# Altium schematic sheet 1_RPi.SchDoc
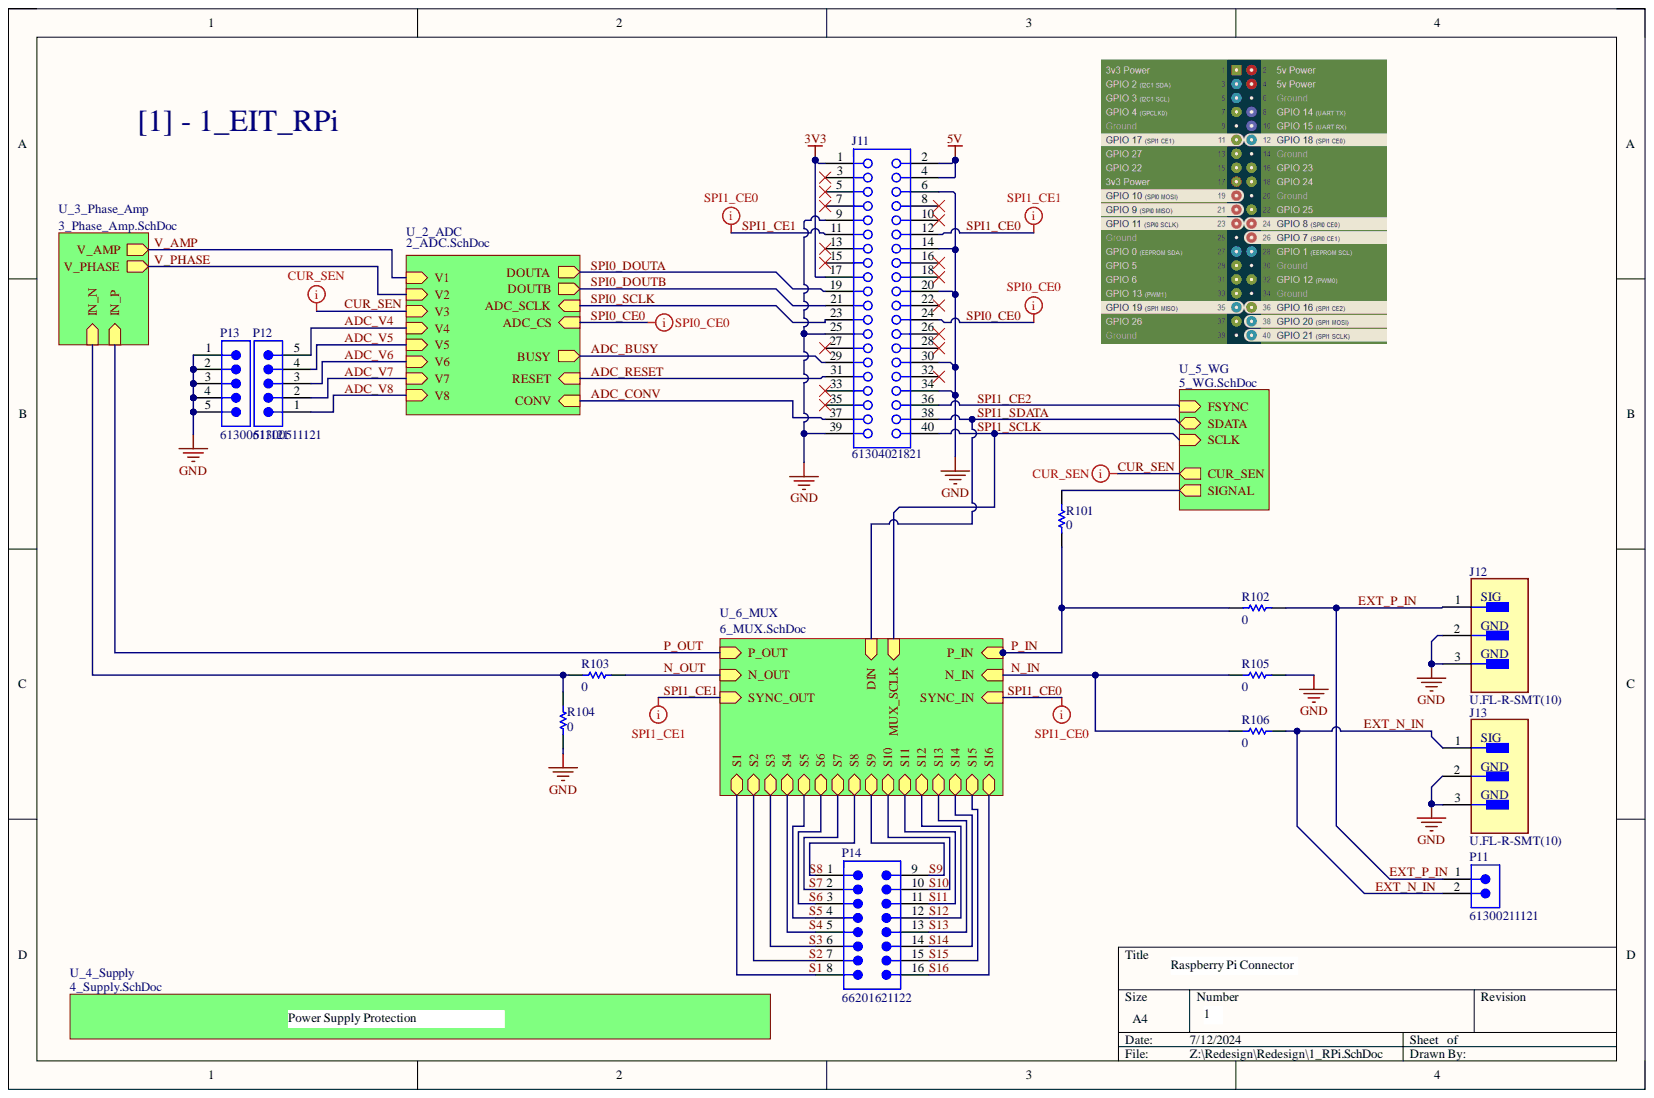
[diagram p0: 1_RPi.SchDoc]

## Parts
J11  61304021821  [61304021821]
J12  U.FL-R-SMT(10)  [FP-U_FL-R-SMT_10-MFG]
J13  U.FL-R-SMT(10)  [FP-U_FL-R-SMT_10-MFG]
P11  61300211121  [61300211121]
P12  61300511121  [61300511121]
P13  61300511121  [61300511121]
P14  66201621122  [66201621122]
R101  RC0603JR-070RL  [RESC1608X55X25LL10T15]  (value not drawn)
R102  RC0603JR-070RL  [RESC1608X55X25LL10T15]  (value not drawn)
R103  RC0603JR-070RL  [RESC1608X55X25LL10T15]  (value not drawn)
R104  RC0603JR-070RL  [RESC1608X55X25LL10T15]  (value not drawn)
R105  RC0603JR-070RL  [RESC1608X55X25LL10T15]  (value not drawn)
R106  RC0603JR-070RL  [RESC1608X55X25LL10T15]  (value not drawn)

## Nets
3V3: J11.1 J11.17
5V: J11.2 J11.4
GND: J11.6 J11.9 J11.14 J11.20 J11.25 J11.30 J11.34 J11.39 J12.2 J12.3 J13.2 J13.3 P13.1 P13.2 P13.3 P13.4 P13.5 R104.2 R105.1
ADC_BUSY: J11.29
ADC_CONV: J11.37
ADC_RESET: J11.31
ADC_V4: P12.5
ADC_V5: P12.4
ADC_V6: P12.3
ADC_V7: P12.2
ADC_V8: P12.1
EXT_N_IN: J13.1 P11.2 R106.1
EXT_P_IN: J12.1 P11.1 R102.1
N_IN: R105.2 R106.2
N_OUT: R103.1
P_IN: R101.2 R102.2
S1: P14.8
S2: P14.7
S3: P14.6
S4: P14.5
S5: P14.4
S6: P14.3
S7: P14.2
S8: P14.1
S9: P14.9
S10: P14.10
S11: P14.11
S12: P14.12
S13: P14.13
S14: P14.14
S15: P14.15
S16: P14.16
SPI0_CE0: J11.24
SPI0_DOUTA: J11.19
SPI0_DOUTB: J11.21
SPI0_SCLK: J11.23
SPI1_CE0: J11.12
SPI1_CE1: J11.11
SPI1_CE2: J11.36
SPI1_SCLK: J11.40
SPI1_SDATA: J11.38
NetR103_2: R103.2 R104.1
CUR_SEN:
P_OUT:
V_AMP:
V_PHASE:

Not connected: J11 3 5 7 8 10 13 15 16 18 22 26 27 28 32 33 35; R101 1

## Library data of the parts
J11 = CMP-1502-01370-3: NexarPartId: 61924769; Contact Resistance: 20mΩ; Current Rating: 3A; Gender: Female; Operating Supply Voltage: 250V; Component Kind: Standard; Lead Pitch: 2.54mm; Library Name: Altium Content Vault; Number of Pins: 40; Orientation: Vertical; RoHS: Non-Compliant
J12 J13 = eef6fde44c591823e8076210edfe12f: CONN U.FL RCPT STR 50 OHM SMD; Body Material: Bronze; Body Plating: Silver; Connector Type: Coaxial; Dielectric Material: Polymer; Frequency: 6GHz; Impedance: 50R; Case/Package: SMD/SMT; Component Kind: Standard; Contact Material: Brass; Contact Plating: Gold; Depth: 3.1mm; Fastening Type: Snap; Housing Color: Beige; Lead Free: Lead Free; Length: 3mm; Max Frequency: 6GHz; Max Operating Temperature: 90°C; Min Operating Temperature: -40°C; Mount: Surface Mount; Orientation: Vertical; Package Quantity: 1; Packaging: Tape and Reel; Plating: Silver; Radiation Hardening: No; Termination: Solder; Library Name: RPI_EIT_SchLib.SchLib
P11 = CMP-1712-00002-6: NexarPartId: 20081794; Connector Type: Male Pin; Contact Resistance: 20mΩ; Current Rating: 3A; Gender: Male; Number of Contacts: 2; Operating Supply Voltage: 250V; Pitch: 2.54mm; Color: Black; Component Kind: Standard; Contact Gender: Male; Contact Material: Copper; Contact Mating Length: 5.9944mm; Contact Plating: Gold; Height: 8.94mm; Housing Material: PBT; Lead Free: Lead Free; Lead Pitch: 2.54mm; Library Name: Altium Content Vault; Max Operating Temperature: 125°C; Min Operating Temperature: -40°C; Mount: Through Hole; Number of Pins: 2; Number of Positions: 2; Number of Rows: 1; Orientation: Vertical; Packaging: Bulk; REACH SVHC: No SVHC; RoHS: Compliant; Termination: Through Hole; Voltage Rating: 250V
P12 P13 = Header_Male_1R_05P: NexarPartId: 32855526; Connector Type: Male Pin; Contact Resistance: 20mΩ; Current Rating: 3A; Gender: Male; Number of Contacts: 5; Operating Supply Voltage: 250V; Pitch: 2.54mm; Color: Black; Component Kind: Standard; Contact Material: Copper; Contact Mating Length: 5.9944mm; Contact Plating: Gold; Height: 8.89mm; Lead Free: Lead Free; Lead Pitch: 2.54mm; Max Operating Temperature: 105°C; Min Operating Temperature: -40°C; Mount: Through Hole; Number of Pins: 5; Number of Positions: 5; Number of Rows: 1; Orientation: Vertical; Packaging: Bulk; REACH SVHC: Unknown; RoHS: Compliant; Termination: Solder; Voltage Rating: 250V; Library Name: RPI_EIT_SchLib.SchLib
P14 = Header_Male_2R_16P(A): NexarPartId: 23024737; Connector Type: Male Pin, Socket; Contact Resistance: 10mΩ; Current Rating: 5A; Gender: Male; Insulation Resistance: 1GΩ; Number of Contacts: 16; Operating Supply Voltage: 250V; Pitch: 3mm; Row Spacing: 2.9972mm; Color: Black; Component Kind: Standard; Contact Material: Copper; Contact Plating: Tin; Fastening Type: Ramp; Height: 9.9mm; Lead Pitch: 3mm; Length: 27.7mm; Max Operating Temperature: 105°C; Min Operating Temperature: -40°C; Mount: Through Hole; Number of Pins: 16; Number of Positions: 16; Number of Rows: 2; Orientation: Vertical; REACH SVHC: Unknown; RoHS: Compliant; Termination: Kinked Pin; Width: 27.7mm; Library Name: RPI_EIT_SchLib.SchLib
R101-R106 = RES-2: Chip Resistor, 0 Ohm, +/- 5%, 0.1 W, -55 to 155 degC, 0603 (1608 Metric), RoHS, Tape and Reel; Manufacturer: Yageo; Power Rating: 100mW; Resistance: 0; Temperature Coefficient: 200ppm/degreesC; Voltage Rating: 50V; Case Code (Imperial): 0603; Case Code (Metric): 1608; Case/Package: 0603; Component Kind: Standard; Composition: ThickFilm; Contact Plating: Tin; Datasheet URL: http://www.yageo.com/NewPortal/yageodocoutput?fileName=/pdf/R-Chip/PYu-RC_Group_51_RoHS_L_5.pdf; Datasheet Version: Rev. 5, 10/2016; Depth: 0.8mm; Height: 0.45mm; Lead Free: LeadFree; Length: 1.6mm; Manufacturer URL: http://www.yageo.com/; Max Operating Temperature: 155degC; Military Standard: Not; Min Operating Temperature: -55degC; Number of Pins: 2; Package Description: 2-Pin Surface Mount Device, Body 1.6 x 0.8 mm; Package Quantity: 5000; Package Reference: 0603; Packaging: TapeandReel; Radiation Hardening: No; REACH SVHC: NoSVHC; RoHSCompliant: true; Termination: SMD/SMT; Tolerance: 5%; Voltage Rating (DC): 50V; Width: 0.8mm; Library Name: RPI_EIT_SchLib.SchLib
